# Altium schematic sheet [4] MCU.SchDoc("[4] MCU")
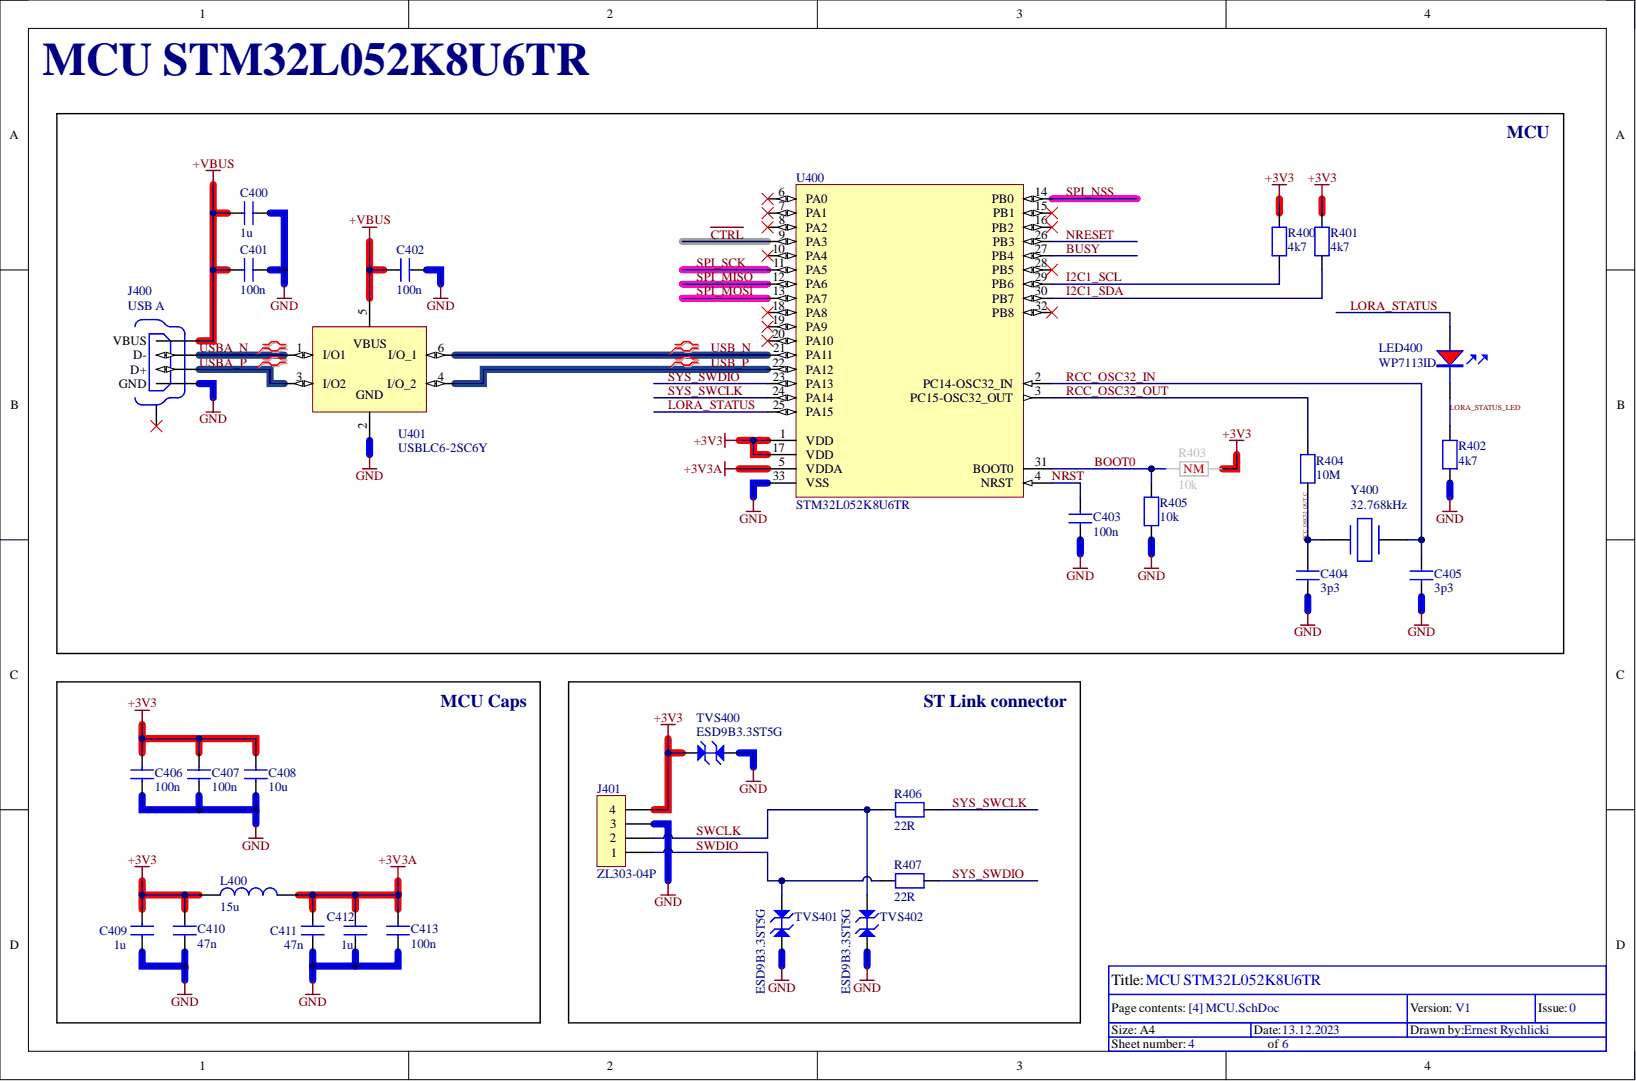
[diagram p0: [4] MCU.SchDoc]

## Parts
C400  1u  [C0402]
C401  100n  [C0402]
C402  100n  [C0402]
C403  100n  [C0603]
C404  3p3  [C0402]
C405  3p3  [C0402]
C406  100n  [C0402]
C407  100n  [C0402]
C408  10u  [C0402]
C409  1u  [C0402]
C410  47n  [C0402]
C411  47n  [C0402]
C412  1u  [C0402]
C413  100n  [C0402]
J400  USB A  [CONN_USB_RT_ANG]
J401  ZL303-04P  [HEADER1X4 _2mm]
L400  15u  [L0805]
LED400  WP7113ID  [LED5mm]
R400  4k7  [R0603]
R401  4k7  [R0603]
R402  4k7  [R0603]
R403  10k  [R0603]
R404  10M  [R0603]
R405  10k  [R0603]
R406  22R  [R0603]
R407  22R  [R0603]
TVS400  ESD9B3.3ST5G  [SOD-923]
TVS401  ESD9B3.3ST5G  [SOD-923]
TVS402  ESD9B3.3ST5G  [SOD-923]
U400  STM32L052K8U6TR  [UFQFPN32]
U401  USBLC6-2SC6Y  [SOT23-6L]
Y400  32.768kHz  [OSCILATOR_2X1_2]

## Nets
+3V3: C406.2 C407.2 C408.2 C409.2 C410.2 J401.4 L400.1 R400.2 R401.2 R403.1 TVS400.1 U400.1(VDD) U400.17(VDD)
+3V3A: C411.2 C412.2 C413.2 L400.2 U400.5(VDDA)
+VBUS: C400.2 C401.2 C402.2 J400.1 U401.5
GND: C400.1 C401.1 C402.1 C403.1 C404.2 C405.2 C406.1 C407.1 C408.1 C409.1 C410.1 C411.1 C412.1 C413.1 J400.4 J401.3 R402.2 R405.1 TVS400.2 TVS401.2 TVS402.2 U400.33(VSS) U401.2
~{CTRL}: U400.9(PA3)
BOOT0: R403.2 R405.2 U400.31(BOOT0)
BUSY: U400.27(PB4)
I2C1_SCL: R400.1 U400.29(PB6)
I2C1_SDA: R401.1 U400.30(PB7)
LORA_STATUS: LED400.A U400.25(PA15)
LORA_STATUS_LED: LED400.K R402.1
NRESET: U400.26(PB3)
NRST: C403.2 U400.4(NRST)
RCC_OSC32_IN: C405.1 U400.2(PC14-OSC32_IN) Y400.2
RCC_OSC32_OUT: R404.2 U400.3(PC15-OSC32_OUT)
RCC_OSC32_OUT_C: C404.1 R404.1 Y400.1
SPI_MISO: U400.12(PA6)
SPI_MOSI: U400.13(PA7)
SPI_NSS: U400.14(PB0)
SPI_SCK: U400.11(PA5)
SWCLK: J401.2 R406.1 TVS402.1
SWDIO: J401.1 R407.1 TVS401.1
SYS_SWCLK: R406.2 U400.24(PA14)
SYS_SWDIO: R407.2 U400.23(PA13)
USB_N: U400.21(PA11) U401.6(I/O_1)
USB_P: U400.22(PA12) U401.4(I/O_2)
USBA_N: J400.2(D-) U401.1(I/O1)
USBA_P: J400.3 U401.3(I/O2)

Not connected: J400 0; U400 6(PA0) 7(PA1) 8(PA2) 10(PA4) 15(PB1) 16(PB2) 18(PA8) 19(PA9) 20(PA10) 28(PB5) 32(PB8)

## Library data of the parts
C400 C409 C412 = 0402X105K100CT: Multilayer Ceramic Capacitor, 1 uF, 10%, X5r, 10 V, 0402 [1005 Metric]; Manufacturer: Walsin Technologies; Category: Ceramic Capacitors; Component Kind: Standard; ComponentLink1Description: http://www.farnell.com/datasheets/2340522.pdf?src-supplier=Farnell; ComponentLink1URL: http://www.farnell.com/datasheets/2340522.pdf?src-supplier=Farnell; ComponentLink2Description: http://www.farnell.com/datasheets/1958513.pdf?src-supplier=Farnell; ComponentLink2URL: http://www.farnell.com/datasheets/1958513.pdf?src-supplier=Farnell; ComponentLink3Description: http://www.farnell.com/datasheets/1958513.pdf?src-supplier=Element14; ComponentLink3URL: http://www.farnell.com/datasheets/1958513.pdf?src-supplier=Element14; ComponentLink4Description: https://datasheet.octopart.com/0402X105K100CT-Walsin-Technologies-datasheet-128065749.pdf?src-supplier=Future+Electronics; ComponentLink4URL: https://datasheet.octopart.com/0402X105K100CT-Walsin-Technologies-datasheet-128065749.pdf?src-supplier=Future+Electronics; ComponentLink5Description: https://datasheet.octopart.com/0402X105K100CT-Walsin-Technologies-datasheet-62066062.pdf?src-supplier=TME; ComponentLink5URL: https://datasheet.octopart.com/0402X105K100CT-Walsin-Technologies-datasheet-62066062.pdf?src-supplier=TME; ComponentLink6Description: https://datasheet.octopart.com/0402X105K100CT-Walsin-Technologies-datasheet-136037192.pdf?src-supplier=TECHDesign; ComponentLink6URL: https://datasheet.octopart.com/0402X105K100CT-Walsin-Technologies-datasheet-136037192.pdf?src-supplier=TECHDesign; Library Name: ER_SCH_LIB.SchLib; Manufacturer Part Number: 0402X105K100CT; Pricing: 10000=0,0037, 20000=0,0027, 50000=0,0026, 100000=0,0025, 250000=0,0023 (USD); RoHS: Yes; Stock: 760000; Supplier: Arrow Electronics; Supplier Part Number: 0402X105K100CT
C401 C402 C406 C407 C413 = 04023C104KAT2A: Multilayer Ceramic Capacitor, 0.1 uF, 25 V, ± 10%, X7R, 0402 [1005 Metric]; Manufacturer: Kyocera AVX; Material: 4241ConductorMaterial; Value: 100nF; Voltage: 25V; Case Code (Imperial): 0402; Case Code (Metric): 1005; Case/Package: 0402; Category: Ceramic Capacitors; Component Kind: Standard; ComponentLink1Description: https://datasheet.ciiva.com/6265/cx7r-6265272.pdf?src-supplier=Digi-Key; ComponentLink1URL: https://datasheet.ciiva.com/6265/cx7r-6265272.pdf?src-supplier=Digi-Key; ComponentLink2Description: https://datasheet.ciiva.com/1123/1679506-1123344.pdf?src-supplier=Element14; ComponentLink2URL: https://datasheet.ciiva.com/1123/1679506-1123344.pdf?src-supplier=Element14; ComponentLink3Description: https://datasheet.ciiva.com/7709/1679506-7709527.pdf?src-supplier=Element14; ComponentLink3URL: https://datasheet.ciiva.com/7709/1679506-7709527.pdf?src-supplier=Element14; ComponentLink4Description: https://datasheet.ciiva.com/14906/cx7r-14906223.pdf?src-supplier=Digi-Key; ComponentLink4URL: https://datasheet.ciiva.com/14906/cx7r-14906223.pdf?src-supplier=Digi-Key; ComponentLink5Description: https://datasheet.ciiva.com/7614/cx7r-7614663.pdf?src-supplier=Digi-Key; ComponentLink5URL: https://datasheet.ciiva.com/7614/cx7r-7614663.pdf?src-supplier=Digi-Key; Dielectric: X7R; Lead Free: Lead Free; Library Name: ER_SCH_LIB.SchLib; Manufacturer Part Number: 04023C104KAT2A; Max Operating Temperature: 125°C; Min Operating Temperature: -55°C; Mounting Technology: Surface Mount; Packaging: Cut Tape; Pins: 2; Pricing: 10000=0,0064, 20000=0,0061, 30000=0,0058, 50000=0,0052, 100000=0,0042, 150000=0,0038 (USD); Reach SVHC Compliant: No; RoHS: Yes; RoHS Compliant: Yes; Series: -; Stock: 5700000; Supplier: Arrow Electronics; Supplier Part Number: 04023C104KAT2A; Tolerance: 10%; Voltage Rating: 25V; Voltage Rating (DC): 25V; Width: 1mm
C403 = 06033C104K4T2A: 0603 0.1 uF 25 V ±10% Tolerance X7R Automotive Multilayer Ceramic Capacitor; Manufacturer: Kyocera AVX; Category: Ceramic Capacitors; Component Kind: Standard; ComponentLink1Description: https://datasheet.ciiva.com/11503/cauto-11503963.pdf?src-supplier=Avnet; ComponentLink1URL: https://datasheet.ciiva.com/11503/cauto-11503963.pdf?src-supplier=Avnet; Library Name: ER_SCH_LIB.SchLib; Manufacturer Part Number: 06033C104K4T2A; Pricing: 4000=0,0188, 8000=0,0175, 12000=0,0166, 20000=0,0164, 24000=0,0157, 100000=0,0126 (USD); RoHS: Yes; Stock: 28000; Supplier: Arrow Electronics; Supplier Part Number: 06033C104K4T2A
C404 C405 = GRM1555C1H3R3BA01D: 0402 3.3 pF 50 V ±0.1 pF Tolerance C0G SMT Multilayer Ceramic Capacitor; Manufacturer: Murata; Category: Ceramic Capacitors; Component Kind: Standard; ComponentLink1Description: https://datasheet.ciiva.com/6270/grm1555c1h3r0wa01-01-6270835.pdf?src-supplier=Digi-Key; ComponentLink1URL: https://datasheet.ciiva.com/6270/grm1555c1h3r0wa01-01-6270835.pdf?src-supplier=Digi-Key; ComponentLink2Description: https://datasheet.ciiva.com/18184/getdatasheetpartid-318269-18184366.pdf?src-supplier=Verical; ComponentLink2URL: https://datasheet.ciiva.com/18184/getdatasheetpartid-318269-18184366.pdf?src-supplier=Verical; ComponentLink3Description: https://datasheet.ciiva.com/11614/product-11614449.pdf?src-supplier=Avnet; ComponentLink3URL: https://datasheet.ciiva.com/11614/product-11614449.pdf?src-supplier=Avnet; ComponentLink4Description: https://datasheet.ciiva.com/3779/grm1555c1h3r3ba0123-3779149.pdf?src-supplier=Arrow+Electronics; ComponentLink4URL: https://datasheet.ciiva.com/3779/grm1555c1h3r3ba0123-3779149.pdf?src-supplier=Arrow+Electronics; ComponentLink5Description: https://datasheet.ciiva.com/6270/partnumbering-e-01-6270837.pdf?src-supplier=Digi-Key; ComponentLink5URL: https://datasheet.ciiva.com/6270/partnumbering-e-01-6270837.pdf?src-supplier=Digi-Key; ComponentLink6Description: https://datasheet.ciiva.com/6270/partnumbering-e-01-6270839.pdf?src-supplier=Digi-Key; ComponentLink6URL: https://datasheet.ciiva.com/6270/partnumbering-e-01-6270839.pdf?src-supplier=Digi-Key; Library Name: ER_SCH_LIB.SchLib; Manufacturer Part Number: GRM1555C1H3R3BA01D; Pricing: 1=0,056, 10=0,0157, 25=0,0153, 100=0,0095, 250=0,0094, 500=0,0089, 1000=0,0084, 3000=0,0078, 6000=0,0073, 15000=0,0068, 30000=0,0063 (USD); RoHS: Yes; Stock: 38428; Supplier: Arrow Electronics; Supplier Part Number: GRM1555C1H3R3BA01D
C408 = GRJ155R60J106ME11D: Capacitor Ceramic Mlcc 0402 10UF 6.3V SMD; Manufacturer: Murata; Tolerance: 20%; Case Code (Imperial): 0402; Case Code (Metric): 1005; Case/Package: 0402; Category: Ceramic Capacitors; Component Kind: Standard; ComponentLink1Description: https://datasheet.octopart.com/GRJ155R60J106ME11D-Murata-datasheet-149522788.pdf?src-supplier=Newark; ComponentLink1URL: https://datasheet.octopart.com/GRJ155R60J106ME11D-Murata-datasheet-149522788.pdf?src-supplier=Newark; ComponentLink2Description: http://psearch.en.murata.com/capacitor/product/GRJ155R60J106ME11%23.pdf?src-supplier=Digi-Key; ComponentLink2URL: http://psearch.en.murata.com/capacitor/product/GRJ155R60J106ME11%23.pdf?src-supplier=Digi-Key; ComponentLink3Description: https://datasheet.octopart.com/GRJ155R60J106ME11D-Murata-datasheet-165579666.pdf?src-supplier=TME; ComponentLink3URL: https://datasheet.octopart.com/GRJ155R60J106ME11D-Murata-datasheet-165579666.pdf?src-supplier=TME; Features: Soft Termination; Length: 0.039inch; Library Name: ER_SCH_LIB.SchLib; Manufacturer Part Number: GRJ155R60J106ME11D; Max Operating Temperature: 85°C; Min Operating Temperature: -55°C; Mounting Technology: Surface Mount; Packaging: Cut Tape; Pricing: 10000=0,0311, 20000=0,0295, 30000=0,0278, 50000=0,0262, 100000=0,0221, 250000=0,0204 (USD); RoHS: Yes; RoHS Compliant: Yes; Series: GRJ; Stock: 10000; Supplier: Arrow Electronics; Supplier Part Number: GRJ155R60J106ME11D; Thickness: 0.028inch; Value: 10uF; Voltage Rating: 6.3V; Width: 0.02inch
C410 C411 = GRM155R71C473KA01J: Cap Ceramic 0.047uF 16V X7R 10% SMD 0402 125°C Paper T/R; Manufacturer: Murata; Category: Ceramic Capacitors; Component Kind: Standard; ComponentLink1Description: https://datasheet.ciiva.com/11608/product-11608168.pdf?src-supplier=Avnet; ComponentLink1URL: https://datasheet.ciiva.com/11608/product-11608168.pdf?src-supplier=Avnet; ComponentLink2Description: https://datasheet.ciiva.com/163/partnumbering-e-01-163786.pdf?src-supplier=Digi-Key; ComponentLink2URL: https://datasheet.ciiva.com/163/partnumbering-e-01-163786.pdf?src-supplier=Digi-Key; Library Name: ER_SCH_LIB.SchLib; Manufacturer Part Number: GRM155R71C473KA01J; Pricing: 1=0,091, 10=0,01, 25=0,0098, 100=0,0064, 250=0,0063, 500=0,0055, 1000=0,0039, 3000=0,0035, 15000=0,0033, 30000=0,0028 (USD); RoHS: Yes; Stock: 49468; Supplier: Arrow Electronics; Supplier Part Number: GRM155R71C473KA01J
J400 = 0676430910: CONN USB RT ANG RECPT TYPE A BLK; Manufacturer: Molex; Component Kind: Standard; ComponentLink1Description: https://datasheet.ciiva.com/6733/676430910-sd-6733437.pdf?src-supplier=Digi-Key; ComponentLink1URL: https://datasheet.ciiva.com/6733/676430910-sd-6733437.pdf?src-supplier=Digi-Key; Library Name: ER_SCH_LIB.SchLib; Manufacturer Part Number: 0676430910; Pricing: 1=1,46, 10=1,13, 50=1,13, 100=1,05, 1000=0,894, 10000=0,83 (USD); RoHS: Yes; Stock: 4035; Supplier: Mouser; Supplier Part Number: 538-67643-0910
J401 = ZL303-04P: Pin Header, 4-Pin, 2mm; Component Kind: Standard; Library Name: ER_SCH_LIB.SchLib
L400 = MLZ2012M150WT000: Inductor Power Shielded Multi-Layer 15uH 20% 2MHz Ferrite 0.25A 1.235Ohm DCR 0805 T/R; Manufacturer: TDK; DC Resistance (DCR): 950mR; Saturation Current: 120mA; Self Resonant Frequency: 40MHz; Case Code (Imperial): 0805; Case Code (Metric): 2012; Case/Package: 0805; Category: Fixed Inductors; Component Kind: Standard; ComponentLink1Description: https://datasheet.ciiva.com/29690/inductor-commercial-decoupling-mlz-en-29690328.pdf?src-supplier=Digi-Key; ComponentLink1URL: https://datasheet.ciiva.com/29690/inductor-commercial-decoupling-mlz-en-29690328.pdf?src-supplier=Digi-Key; ComponentLink2Description: https://datasheet.ciiva.com/29690/inductor-commercial-decoupling-mlz-en-29690327.pdf?src-supplier=Digi-Key; ComponentLink2URL: https://datasheet.ciiva.com/29690/inductor-commercial-decoupling-mlz-en-29690327.pdf?src-supplier=Digi-Key; ComponentLink3Description: https://datasheet.ciiva.com/29690/inductor-commercial-decoupling-mlz-en-29690329.pdf?src-supplier=Digi-Key; ComponentLink3URL: https://datasheet.ciiva.com/29690/inductor-commercial-decoupling-mlz-en-29690329.pdf?src-supplier=Digi-Key; Core Material: Ferrite; Library Name: ER_SCH_LIB.SchLib; Manufacturer Part Number: MLZ2012M150WT000; Max Operating Temperature: 125°C; Min Operating Temperature: -55°C; Mounting Technology: Surface Mount; Packaging: Cut Tape; Pricing: 2000=0,039, 10000=0,037, 24000=0,036, 50000=0,035, 100000=0,034 (USD); RoHS: Yes; RoHS Compliant: Yes; Series: MLZ; Shielding: Shielded; Stock: 2000; Supplier: Arrow Electronics; Supplier Part Number: MLZ2012M150WT000; Test Frequency: 2MHz; Tolerance: 20%; Value: 15uH
LED400 = WP7113ID: Red T-1 3/4 5 mm 30° 50 mcd 2.2 V Tinted Solid State LED Lamp Through Hole; Manufacturer: Kingbright; Category: LEDs; Component Kind: Standard; ComponentLink1Description: https://datasheet.ciiva.com/2114/wp7113id-2114384.pdf?src-supplier=Digi-Key; ComponentLink1URL: https://datasheet.ciiva.com/2114/wp7113id-2114384.pdf?src-supplier=Digi-Key; ComponentLink2Description: https://datasheet.ciiva.com/4692/wp7113id-48891-4692862.pdf?src-supplier=Mouser; ComponentLink2URL: https://datasheet.ciiva.com/4692/wp7113id-48891-4692862.pdf?src-supplier=Mouser; ComponentLink3Description: https://datasheet.ciiva.com/16210/wp7113id-16210118.pdf?src-supplier=Future+Electronics; ComponentLink3URL: https://datasheet.ciiva.com/16210/wp7113id-16210118.pdf?src-supplier=Future+Electronics; Library Name: ER_SCH_LIB.SchLib; Manufacturer Part Number: WP7113ID; Pricing: 1=0,1, 10=0,072, 50=0,072, 100=0,072, 1000=0,068, 10000=0,06 (USD); RoHS: Yes; Stock: 35900; Supplier: Mouser; Supplier Part Number: 604-WP7113ID
R400-R402 = AF0603FR-074K7L: Res Thick Film 0603 4.7K Ohm 1% 1/10W ±100ppm/°C Molded SMD Paper T/R; Manufacturer: Yageo; Category: Chip SMD Resistors; Component Kind: Standard; ComponentLink1Description: http://www.mouser.com/ds/2/447/PYu-AF_51_RoHS_L_5-1085711.pdf?src-supplier=Mouser; ComponentLink1URL: http://www.mouser.com/ds/2/447/PYu-AF_51_RoHS_L_5-1085711.pdf?src-supplier=Mouser; ComponentLink2Description: https://datasheet.octopart.com/AF0603FR-074K7L-Yageo-datasheet-164730775.pdf?src-supplier=Future+Electronics; ComponentLink2URL: https://datasheet.octopart.com/AF0603FR-074K7L-Yageo-datasheet-164730775.pdf?src-supplier=Future+Electronics; ComponentLink3Description: http://www.yageo.com/documents/recent/PYu-AF_51_RoHS_L_5.pdf?src-supplier=Digi-Key; ComponentLink3URL: http://www.yageo.com/documents/recent/PYu-AF_51_RoHS_L_5.pdf?src-supplier=Digi-Key; Library Name: ER_SCH_LIB.SchLib; Manufacturer Part Number: AF0603FR-074K7L; Pricing: 1=0,0339, 10=0,0163, 25=0,0156, 100=0,0114 (USD); RoHS: Yes; Stock: 178; Supplier: Arrow Electronics; Supplier Part Number: AF0603FR-074K7L
R403 R405 = AC0603JR-0710KL: SMD Chip Resistor, 10 kOhm, ± 5%, 100 mW, 0603 [1608 Metric], Thick Film, General Purpose; Manufacturer: Yageo; Max Power Dissipation: 100mW; Temperature Coefficient: 100ppm/°C; Value: 10kR; Voltage Rating: 50V; Case Code (Imperial): 0603; Case Code (Metric): 1608; Case/Package: 0603; Category: Chip SMD Resistors; Component Kind: Standard; ComponentLink1Description: https://datasheet.ciiva.com/5562/pyu-ac-51-rohs-l-2-5562553.pdf?src-supplier=Mouser; ComponentLink1URL: https://datasheet.ciiva.com/5562/pyu-ac-51-rohs-l-2-5562553.pdf?src-supplier=Mouser; Features: Moisture Resistant,Automotive AEC-Q200; Lead Free: Lead Free; Library Name: ER_SCH_LIB.SchLib; Manufacturer Part Number: AC0603JR-0710KL; Max Operating Temperature: 155°C; Min Operating Temperature: -55°C; Number of Terminations: 2; Packaging: Tape and Reel; Power Rating: 100mW; Pricing: 1=0,0527, 10=0,012, 25=0,0107, 100=0,007, 250=0,0069, 500=0,0062 (USD); Ratings: AEC-Q200; RoHS: Yes; RoHS Compliant: Yes; Series: AC; Stock: 801; Supplier: Arrow Electronics; Supplier Part Number: AC0603JR-0710KL; Termination: SMD/SMT; Tolerance: 5%
R404 = RC0603FR-0710ML: SMD Chip Resistor, 10 MOhm, ± 1%, 100 mW, 0603 [1608 Metric], Thick Film, General Purpose; Manufacturer: Yageo; Max Power Dissipation: 100mW; Temperature Coefficient: 100ppm/°C; Tolerance: 5%; Value: 10MR; Voltage Rating: 50V; Case Code (Imperial): 0603; Case Code (Metric): 1608; Case/Package: 0603; Category: Chip SMD Resistors; Component Kind: Standard; ComponentLink1Description: https://datasheet.ciiva.com/11808/0900766b80f96399-11808122.pdf?src-supplier=Rs; ComponentLink1URL: https://datasheet.ciiva.com/11808/0900766b80f96399-11808122.pdf?src-supplier=Rs; ComponentLink2Description: https://datasheet.ciiva.com/22763/1686128-22763599.pdf?src-supplier=Farnell; ComponentLink2URL: https://datasheet.ciiva.com/22763/1686128-22763599.pdf?src-supplier=Farnell; ComponentLink3Description: https://datasheet.ciiva.com/46393/1868787-46393000.pdf?src-supplier=Element14; ComponentLink3URL: https://datasheet.ciiva.com/46393/1868787-46393000.pdf?src-supplier=Element14; ComponentLink4Description: https://datasheet.ciiva.com/2453/pyu-r-int-thick-7-2453905.pdf?src-supplier=Digi-Key; ComponentLink4URL: https://datasheet.ciiva.com/2453/pyu-r-int-thick-7-2453905.pdf?src-supplier=Digi-Key; ComponentLink5Description: https://datasheet.ciiva.com/2453/pyu-r-int-thick-7-2453906.pdf?src-supplier=Digi-Key; ComponentLink5URL: https://datasheet.ciiva.com/2453/pyu-r-int-thick-7-2453906.pdf?src-supplier=Digi-Key; ComponentLink6Description: https://datasheet.ciiva.com/2453/pyu-r-int-thick-7-2453904.pdf?src-supplier=Digi-Key; ComponentLink6URL: https://datasheet.ciiva.com/2453/pyu-r-int-thick-7-2453904.pdf?src-supplier=Digi-Key; Construction: Rectangular; Depth: 0.8mm; Features: Moisture Resistant; Height: 0.45mm; Lead Free: 5697LeadFree; Length: 1.6mm; Library Name: ER_SCH_LIB.SchLib; Manufacturer Part Number: RC0603FR-0710ML; Max Operating Temperature: 155°C; Military Standard: Not; Min Operating Temperature: -55°C; Number of Terminations: 2; Packaging: Tape and Reel; Pins: 2; Power Rating: 100mW; Pricing: 5000=0,002 (USD); Reach SVHC Compliant: No; Resistance Tolerance: 1%; Resistor Type: Thick Film; RoHS: Yes; RoHS Compliant: Yes; Series: RC; Stock: 125000; Supplier: Arrow Electronics; Supplier Part Number: RC0603FR-0710ML; Technology: THICK FILM; Termination: SMD/SMT; Voltage Rating (AC): 50V; Voltage Rating (DC): 50V; Width: 0.8mm
R406 R407 = RC0603JR-0722RL: SMD Chip Resistor, 22 Ohm, ± 5%, 100 mW, 0603 [1608 Metric], Thick Film, General Purpose; Manufacturer: Yageo; Voltage Rating (AC): 50V; Category: Chip SMD Resistors; Component Kind: Standard; ComponentLink1Description: https://datasheet.ciiva.com/7746/443971-7746471.pdf?src-supplier=Element14; ComponentLink1URL: https://datasheet.ciiva.com/7746/443971-7746471.pdf?src-supplier=Element14; ComponentLink2Description: https://datasheet.ciiva.com/7746/443971-7746472.pdf?src-supplier=Element14; ComponentLink2URL: https://datasheet.ciiva.com/7746/443971-7746472.pdf?src-supplier=Element14; ComponentLink3Description: https://datasheet.ciiva.com/46394/443971-46394999.pdf?src-supplier=Element14; ComponentLink3URL: https://datasheet.ciiva.com/46394/443971-46394999.pdf?src-supplier=Element14; ComponentLink4Description: https://datasheet.ciiva.com/15189/getdatasheetpartid-493085-15189862.pdf?src-supplier=Verical; ComponentLink4URL: https://datasheet.ciiva.com/15189/getdatasheetpartid-493085-15189862.pdf?src-supplier=Verical; Library Name: ER_SCH_LIB.SchLib; Manufacturer Part Number: RC0603JR-0722RL; Pricing: 1=0,0925, 10=0,0259, 25=0,0135, 100=0,005, 250=0,0047, 500=0,0043, 1000=0,0042, 3000=0,0026 (USD); RoHS: Yes; Stock: 4035; Supplier: Arrow Electronics; Supplier Part Number: RC0603JR-0722RL
TVS400-TVS402 = ESD9B3.3ST5G: 11.5V 2A 3.3V (Max) 5V Bidirectional SOD-923 ESD Protection Devices ROHS; Manufacturer: ON Semiconductor; Clamping Voltage: 10.5V; Diode Type: ZENER; ESD Protection: Yes; Leakage Current: 1uA; Max Power Dissipation: 300mW; Number of Bidirectional Channels: 1; Power Line Protection: No; Test Current: 1mA; Value: 15pF; Breakdown Voltage: 5V; Case/Package: SOD-923-2; Category: ESD and Circuit Protection ICs; Component Kind: Standard; ComponentLink1Description: https://datasheet.ciiva.com/339/esd9b-d-339712.pdf?src-supplier=Mouser; ComponentLink1URL: https://datasheet.ciiva.com/339/esd9b-d-339712.pdf?src-supplier=Mouser; ComponentLink2Description: https://datasheet.ciiva.com/22642/1596367-22642026.pdf?src-supplier=Element14; ComponentLink2URL: https://datasheet.ciiva.com/22642/1596367-22642026.pdf?src-supplier=Element14; ComponentLink3Description: https://datasheet.ciiva.com/22642/1596367-22642028.pdf?src-supplier=Element14; ComponentLink3URL: https://datasheet.ciiva.com/22642/1596367-22642028.pdf?src-supplier=Element14; ComponentLink4Description: https://datasheet.ciiva.com/11193/esd9b-d-11193999.pdf?src-supplier=Avnet; ComponentLink4URL: https://datasheet.ciiva.com/11193/esd9b-d-11193999.pdf?src-supplier=Avnet; ComponentLink5Description: https://datasheet.ciiva.com/26917/getdatasheetpartid-292713-26917946.pdf?src-supplier=Verical; ComponentLink5URL: https://datasheet.ciiva.com/26917/getdatasheetpartid-292713-26917946.pdf?src-supplier=Verical; Depth: 0.6mm; Direction: Bidirectional; Halogen Free: Halogen Free; Height: 0.4mm; Lead Free: Lead Free; Length: 0.8mm; Library Name: ER_SCH_LIB.SchLib; Manufacturer Part Number: ESD9B3.3ST5G; Max Operating Temperature: 150°C; Max Reverse Leakage Current: 1uA; Min Breakdown Voltage: 5V; Min Operating Temperature: -55°C; Number of Channels: 1; Number of Elements: 1; Number of Lines: 1; Operating Supply Voltage: 3.3V; Package Quantity: 8000; Packaging: Tape and Reel; Peak Pulse Current: 2A; Peak Pulse Power: 300mW; Pins: 2; Polarity: Bidirectional; Pricing: 1=0,1559, 10=0,1305, 25=0,108, 100=0,067 (USD); Radiation Hardening: No; Reach SVHC Compliant: No; Reverse Breakdown Voltage: 5V; Reverse Standoff Voltage: 3.3V; RoHS: Yes; RoHS Compliant: Yes; Series: -; Stock: 102; Supplier: Arrow Electronics; Supplier Part Number: ESD9B3.3ST5G; Termination: SMD/SMT; Width: 0.65mm; Working Voltage: 3.3V
U400 = STM32L052K8U6TR: Ultra-low power ARM Cortex-M0+ MCU with 64-Kbyte Flash, 32 MHz CPU, USB; Manufacturer: STMicroelectronics; Density: 64KB; Nominal Supply Current: 290nA; Number of Channels: 10NUMBER; Number of D/A Converters: 1NUMBER; Number of Timers/Counters: 4NUMBER; Category: Microcontrollers; Component Kind: Standard; ComponentLink1Description: http://www.mouser.com/ds/2/389/stm32l052c6-956243.pdf?src-supplier=Mouser; ComponentLink1URL: http://www.mouser.com/ds/2/389/stm32l052c6-956243.pdf?src-supplier=Mouser; ComponentLink2Description: http://www.farnell.com/datasheets/1964277.pdf?src-supplier=Farnell; ComponentLink2URL: http://www.farnell.com/datasheets/1964277.pdf?src-supplier=Farnell; ComponentLink3Description: http://www.st.com/content/ccc/resource/technical/document/datasheet/dc/d6/6d/24/86/2a/40/d3/DM00108217.pdf/files/DM00108217.pdf/jcr:content/translations/en.DM00108217.pdf?src-supplier=Digi-Key; ComponentLink3URL: http://www.st.com/content/ccc/resource/technical/document/datasheet/dc/d6/6d/24/86/2a/40/d3/DM00108217.pdf/files/DM00108217.pdf/jcr:content/translations/en.DM00108217.pdf?src-supplier=Digi-Key; ComponentLink4Description: https://datasheet.octopart.com/STM32L052K8U6TR-STMicroelectronics-datasheet-62336777.pdf?src-supplier=Future+Electronics; ComponentLink4URL: https://datasheet.octopart.com/STM32L052K8U6TR-STMicroelectronics-datasheet-62336777.pdf?src-supplier=Future+Electronics; ComponentLink5Description: http://www.st.com/st-web-ui/static/active/en/resource/technical/document/datasheet/DM00108217.pdf?src-supplier=Avnet; ComponentLink5URL: http://www.st.com/st-web-ui/static/active/en/resource/technical/document/datasheet/DM00108217.pdf?src-supplier=Avnet; ComponentLink6Description: https://datasheet.octopart.com/STM32L052K8U6TR-STMicroelectronics-datasheet-48110660.pdf?src-supplier=Newark; ComponentLink6URL: https://datasheet.octopart.com/STM32L052K8U6TR-STMicroelectronics-datasheet-48110660.pdf?src-supplier=Newark; ComponentLink7Description: https://datasheet.octopart.com/STM32L052K8U6TR-STMicroelectronics-datasheet-38726991.pdf?src-supplier=Element14; ComponentLink7URL: https://datasheet.octopart.com/STM32L052K8U6TR-STMicroelectronics-datasheet-38726991.pdf?src-supplier=Element14; ComponentLink8Description: https://datasheet.octopart.com/STM32L052K8U6TR-STMicroelectronics-datasheet-159009248.pdf?src-supplier=SHENGYU+ELECTRONICS; ComponentLink8URL: https://datasheet.octopart.com/STM32L052K8U6TR-STMicroelectronics-datasheet-159009248.pdf?src-supplier=SHENGYU+ELECTRONICS; Library Name: ER_SCH_LIB.SchLib; Manufacturer Part Number: STM32L052K8U6TR; Number of I2C Channels: 1NUMBER; Number of SPI Channels: 1NUMBER; Pricing: 1=4,52, 10=4,06, 50=3,84, 100=3,33, 1000=2,39, 10000=2,19 (USD); RoHS: Yes; Stock: 12130; Supplier: Mouser; Supplier Part Number: 511-STM32L052K8U6TR
U401 = USBLC6-2SC6Y: USBLC6 Series 2 Line 6 V Uni / Bi Directional ESD Protection - SOT-23-6; Manufacturer: STMicroelectronics; Breakdown Voltage: 6V; Clamping Voltage: 17V; Direction: Unidirectional; ESD Protection: Yes; ESR: 330R; Leakage Current: 150nA; Number of Bidirectional Channels: 2; Operating Supply Voltage: 1.1V; Peak Pulse Current: 5A; Reverse Standoff Voltage: 5.25V; Test Current: 1mA; Value: 3.5pF; Case/Package: SOT-23; Category: TVS Diodes; Component Kind: Standard; ComponentLink1Description: https://datasheet.ciiva.com/12326/dm00055262-101391-12326647.pdf?src-supplier=Mouser; ComponentLink1URL: https://datasheet.ciiva.com/12326/dm00055262-101391-12326647.pdf?src-supplier=Mouser; ComponentLink2Description: https://datasheet.ciiva.com/338/dm00055262-338888.pdf?src-supplier=Digi-Key; ComponentLink2URL: https://datasheet.ciiva.com/338/dm00055262-338888.pdf?src-supplier=Digi-Key; ComponentLink3Description: https://datasheet.ciiva.com/338/dm00055262-338887.pdf?src-supplier=Digi-Key; ComponentLink3URL: https://datasheet.ciiva.com/338/dm00055262-338887.pdf?src-supplier=Digi-Key; ComponentLink4Description: https://datasheet.ciiva.com/338/dm00055262-338889.pdf?src-supplier=Digi-Key; ComponentLink4URL: https://datasheet.ciiva.com/338/dm00055262-338889.pdf?src-supplier=Digi-Key; ComponentLink5Description: https://datasheet.ciiva.com/41442/001183856-da-01-en-diode-esd-2lin-usblc6-2sc6y-sot-23-6-stm-41442876.pdf?src-supplier=Conrad; ComponentLink5URL: https://datasheet.ciiva.com/41442/001183856-da-01-en-diode-esd-2lin-usblc6-2sc6y-sot-23-6-stm-41442876.pdf?src-supplier=Conrad; Depth: 1.75mm; Height: 1.45mm; Lead Free: Lead Free; Length: 3mm; Library Name: ER_SCH_LIB.SchLib; Manufacturer Part Number: USBLC6-2SC6Y; Max Operating Temperature: 150°C; Max Reverse Leakage Current: 150nA; Min Breakdown Voltage: 6V; Min Operating Temperature: -40°C; Mounting Technology: Surface Mount; Number of Channels: 2; Number of Unidirectional Channels: 2; Package Quantity: 1; Packaging: Tape and Reel; Pins: 6; Power Line Protection: Yes; Pricing: 1=0,94, 10=0,847, 50=0,847, 100=0,691, 1000=0,541, 10000=0,426 (USD); Radiation Hardening: No; Reach SVHC Compliant: No; RoHS: Yes; RoHS Compliant: Yes; Series: Automotive, AEC-Q101, USBY; Stock: 6497; Supplier: Mouser; Supplier Part Number: 511-USBLC6-2SC6Y; Termination: SMD/SMT; Width: 1.75mm
Y400 = CM8V-T1A 32.768kHz 7pF +/-20ppm TA QC: Tuning Fork Crystal SMD 32.768kHz 1.2x2.0mm; Manufacturer: Micro Crystal; Frequency Tolerance: 20ppm; Load Capacitance: 7pF; Case/Package: SMD/SMT; Category: Uncategorized; Component Kind: Standard; ComponentLink1Description: https://datasheet.ciiva.com/29123/001009262-da-01-en-uhrenquarz-cm8v-t1a-32-768-247-smd-29123814.pdf?src-supplier=Conrad; ComponentLink1URL: https://datasheet.ciiva.com/29123/001009262-da-01-en-uhrenquarz-cm8v-t1a-32-768-247-smd-29123814.pdf?src-supplier=Conrad; Frequency: 32.768kHz; Height: 0.6mm; Length: 2mm; Library Name: ER_SCH_LIB.SchLib; Manufacturer Part Number: CM8V-T1A 32.768kHz 7pF +/-20ppm TA QC; Max Operating Temperature: 85°C; Min Operating Temperature: -40°C; Pricing: 1=0,6, 10=0,502, 50=0,4522, 100=0,402, 500=0,38188 (USD); RoHS: Yes; Stock: 101880; Supplier: Digi-Key; Supplier Part Number: 2195-CM8V-T1A-32.768KHZ-7PF-20PPM-TA-QCCT-ND; Width: 1.2mm
